# Altium schematic sheet UART TO USB.SchDoc
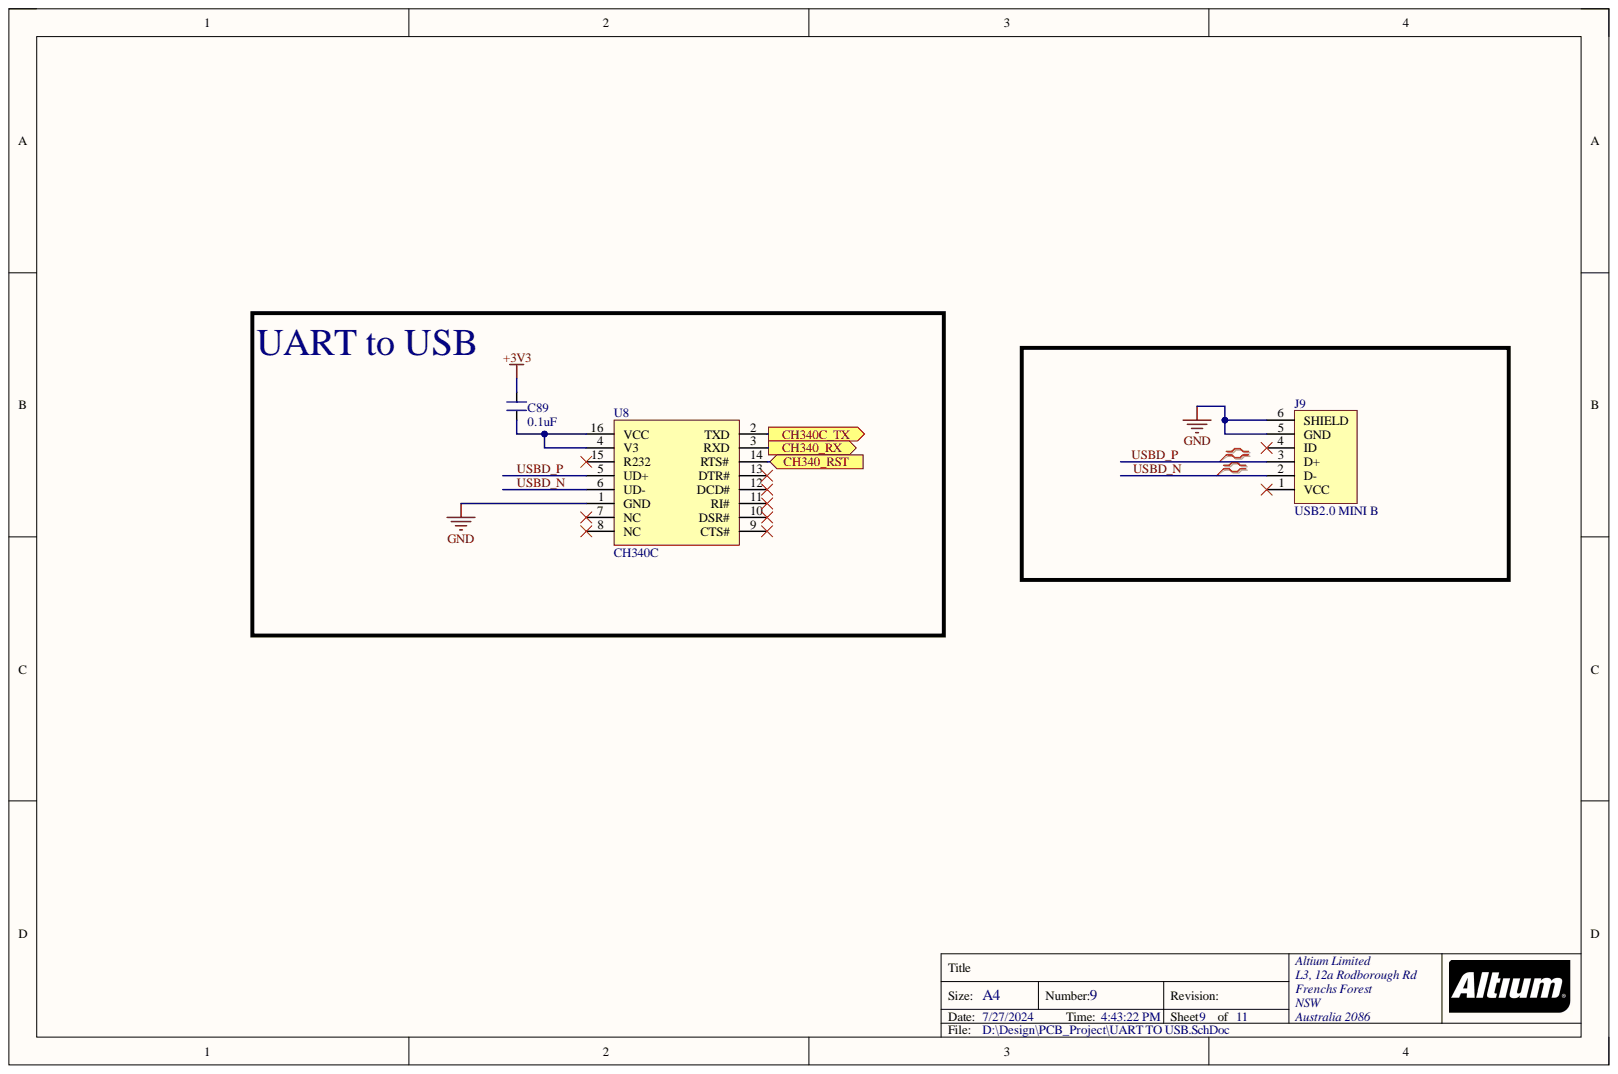
[diagram p0: UART TO USB.SchDoc]

## Parts
C89  0.1uF  [CAP_0805]
J9  USB2.0 MINI B  [CON_690-005-299-043]
U8  CH340C  [SOIC16_50_284_25X90_SMT]

## Ports
CH340_RST, CH340_RX, CH340C_TX

## Nets
+3V3: C89.2
GND: J9.5(GND) J9.6(SHIELD) U8.1(GND)
CH340_RST: U8.14(RTS#) ; port CH340_RST
CH340_RX: U8.3(RXD) ; port CH340_RX
CH340C_TX: U8.2(TXD) ; port CH340C_TX
USBD_9_N: J9.2(D-) U8.6(UD-)  [also labelled USBD_N]
USBD_9_P: J9.3(D+) U8.5(UD+)  [also labelled USBD_P]
NetC89_1: C89.1 U8.4(V3) U8.16(VCC)
NetJ9_1: J9.1(VCC)
NetJ9_4: J9.4(ID)
NetU8_7: U8.7(NC)
NetU8_8: U8.8(NC)
NetU8_9: U8.9(CTS#)
NetU8_10: U8.10(DSR#)
NetU8_11: U8.11(RI#)
NetU8_12: U8.12(DCD#)
NetU8_13: U8.13(DTR#)
NetU8_15: U8.15(R232)

## Library data of the parts
C89 = CAP_0.1uF-50V-10%-0805: 0.1µF ±10% 50V Ceramic Capacitor X7R 0805 (2012 Metric); Alternate Part Number-1: NA; Manufacturer: Samsung; Manufacturer Part Number: CL21B104KBCNNNC; Case/Package: 0805; Component Kind: Standard; Library Name: ESTEEMPCBLIB.IntLib; Supplier Part Number-1: 0805B104K500CT; Supplier-1: SIRICOM
J9 = CON_USB2.0-MINIB-SMD: USB - mini B USB 2.0 Receptacle Connector 5 Position Surface Mount, Right Angle; Alternate Part Number-1: NA; Manufacturer: EDAC; Manufacturer Part Number: 690-005-299-043; Case/Package: USB-MINI-B; Component Kind: Standard; Library Name: ESTEEMPCBLIB.IntLib; Supplier Part Number-1: C105424; Supplier-1: LCSC
U8 = IC_CH340C: USB Bridge, USB to UART USB 2.0 UART Interface; Alternate Part Number-1: NA; Manufacturer: Olimex; Case/Package: SOIC16; Component Kind: Standard; Library Name: ESTEEMPCBLIB.IntLib; Manufacturer Part Number: CH340C; Supplier Part Number-1: C84681; Supplier-1: LCSC
